# Altium schematic sheet besc drive sch.SchDoc
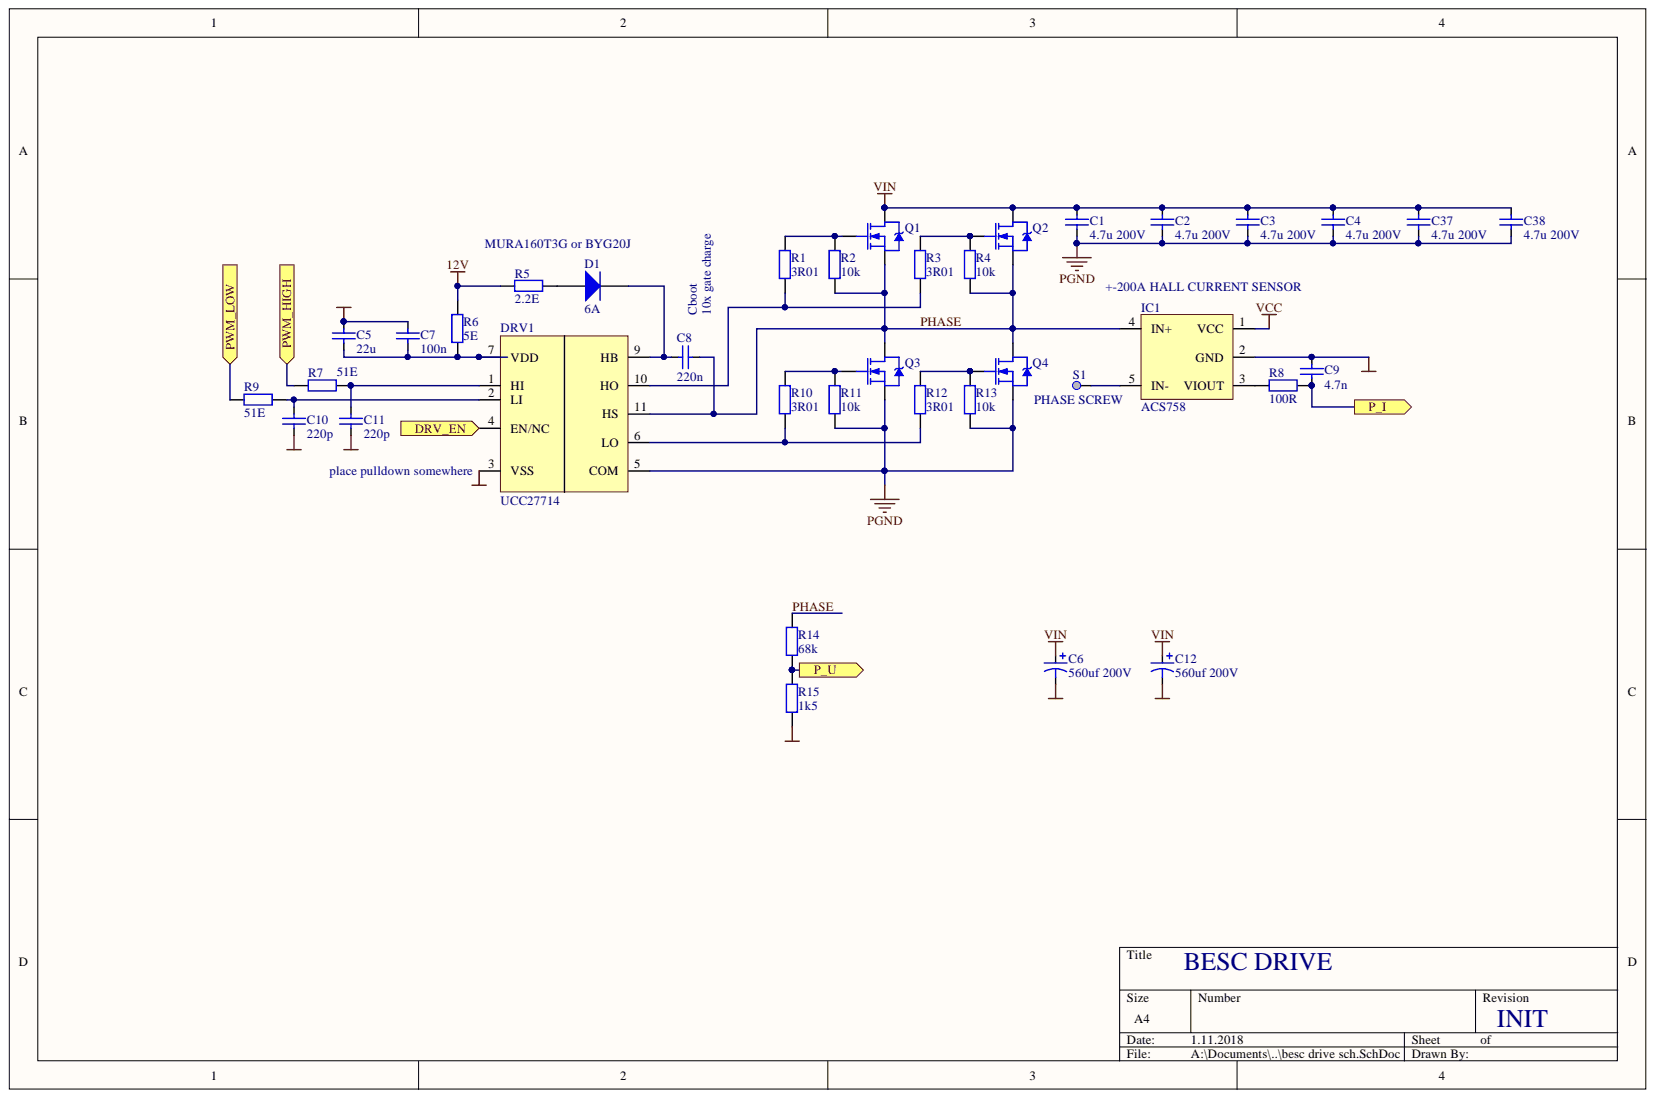
[diagram p0: besc drive sch.SchDoc]

## Parts
C1  4.7u 200V  [CAP 2220/5650 3.3MM]
C2  4.7u 200V  [CAP 2220/5650 3.3MM]
C3  4.7u 200V  [CAP 2220/5650 3.3MM]
C4  4.7u 200V  [CAP 2220/5650 3.3MM]
C5  22u  [0805]
C6  560uf 200V  [ELKO_18x40_90deg]
C7  100n  [0603]
C8  220n  [0603]
C9  4.7n  [0603]
C10  220p  [0603]
C11  220p  [0603]
C12  560uf 200V  [ELKO_18x40_90deg]
C37  4.7u 200V  [CAP 2220/5650 3.3MM]
C38  4.7u 200V  [CAP 2220/5650 3.3MM]
D1  6A  [DO-214AC]
DRV1  UCC27714  [SOIC14_M]
IC1  ACS758  [ACS758]
Q1  IPB044N15N5  [Dpak-7]  (value not drawn)
Q2  IPB044N15N5  [Dpak-7]  (value not drawn)
Q3  IPB044N15N5  [Dpak-7]  (value not drawn)
Q4  IPB044N15N5  [Dpak-7]  (value not drawn)
R1  3R01  [0603]
R2  10k  [0603]
R3  3R01  [0603]
R4  10k  [0603]
R5  2.2E  [0805]
R6  5E  [0603]
R7  51E  [0603]
R8  100R  [0603]
R9  51E  [0603]
R10  3R01  [0603]
R11  10k  [0603]
R12  3R01  [0603]
R13  10k  [0603]
R14  68k  [0603]
R15  1k5  [0603]
S1  PHASE SCREW  [WP-SHFU-M6]

## Ports
DRV_EN, P_I, P_U, PWM_HIGH, PWM_LOW

## Nets
12V: R5.2 R6.1
LGND: C5.2 C7.2 C9.2 C10.2 C11.2 DRV1.3(VSS) IC1.2(GND) R15.2
PGND: C1.1 C2.1 C3.1 C4.1 C6.2 C12.2 C37.1 C38.1 DRV1.5(COM) Q3.2 Q4.2 R11.2 R13.2
VCC: IC1.1(VCC)
VIN: C1.2 C2.2 C3.2 C4.2 C6.1 C12.1 C37.2 C38.2 Q1.3 Q2.3
DRV_EN: DRV1.4(EN/NC) ; port DRV_EN
P_I: C9.1 R8.1 ; port P_I
P_U: R14.2 R15.1 ; port P_U
PHASE: C8.1 DRV1.11(HS) IC1.4(IN+) Q1.2 Q2.2 Q3.3 Q4.3 R2.2 R4.2 R14.1
PWM_HIGH: R7.2 ; port PWM_HIGH
PWM_LOW: R9.1 ; port PWM_LOW
NetC5_1: C5.1 C7.1 DRV1.7(VDD) R6.2
NetC8_2: C8.2 D1.2 DRV1.9(HB)
NetC10_1: C10.1 DRV1.2(LI) R9.2
NetC11_1: C11.1 DRV1.1(HI) R7.1
NetD1_1: D1.1 R5.1
NetDRV1_6: DRV1.6(LO) R10.2 R12.2
NetDRV1_10: DRV1.10(HO) R1.2 R3.2
NetIC1_3: IC1.3(VIOUT) R8.2
NetIC1_5: IC1.5(IN-) S1.1
NetQ1_1: Q1.1 R1.1 R2.1
NetQ2_1: Q2.1 R3.1 R4.1
NetQ3_1: Q3.1 R10.1 R11.1
NetQ4_1: Q4.1 R12.1 R13.1

## Library data of the parts
C1-C5 C7-C11 C37 C38 = cap: Capacitor; Component Kind: Standard; LatestRevisionDate: 17-Jul-2002; LatestRevisionNote: Re-released for DXP Platform.; Library Name: Miscellaneous Devices.IntLib; PackageDescription: Radial Cap, Thru-Hole; 2 Leads; 0.3 in Pin Spacing; PackageReference: RAD-0.3; Published: 8-Jun-2000; Signal Integrity: Cap; Simulation: CAP; Publisher: Altium Limited
  C1: url: https://www.aliexpress.com/item/5pcs-SMD-capacitor-5750-2220-4-7UF-200V-250V-475K-X7R-10-Non-polarity/32633946304.html?spm=2114.search0104.3.15.470c328aPXtbgJ&ws_ab_test=searchweb0_0,searchweb201602_1_10065_10068_318_319_5731311_317_10696_5728811_10084_10083_10618_452_10304_10307_5731411_10820_532_10301_10821_5731211_5731111_204_328_10059_10884_323_10887_5731511_100031_5731611_320_321_322_5731711_10103,searchweb201603_55,ppcSwitch_0&algo_expid=2440df57-4916-4206-b13e-2c99e03a8745-2&algo_pvid=2440df57-4916-4206-b13e-2c99e03a8745
C6 C12 = Cap Pol1: Polarized Capacitor (Radial); Component Kind: Standard; LatestRevisionDate: 17-Jul-2002; LatestRevisionNote: Re-released for DXP Platform.; Library Name: Miscellaneous Devices.IntLib; PackageDescription: Polarized Capacitor (Radial); 2 Leads; PackageReference: RB7.6-15; Published: 8-Jun-2000; Signal Integrity: Cap Pol; Simulation: CAP; Publisher: Altium Limited
D1 = Diode 1N4148: High Conductance Fast Diode; Code_JEDEC: DO-204-AH; Component Kind: Standard; ComponentLink1Description: Manufacturer Link; ComponentLink1URL: http://www.fairchildsemi.com/; ComponentLink2Description: Datasheet; ComponentLink2URL: http://www.fairchildsemi.com/ds/1N%2F1N4148.pdf; DatasheetVersion: 1997; LatestRevisionDate: 17-Jul-2002; LatestRevisionNote: Re-released for DXP Platform.; Library Name: Miscellaneous Devices.IntLib; PackageDescription: Diode, Axial; Body 3.8 x 1.9 mm (LxDia. max), Lead Dia. 0.53 mm (max); PackageReference: DO-35; Published: 8-Jun-2000; Signal Integrity: 1N4148; Simulation: 1N4148; Publisher: Altium Limited
Q1-Q4 = NMOS-2: N-Channel Power MOSFET; Note: Pin 2 is electrically connected to pin 4.; Component Kind: Standard; ComponentLink1Description: Manufacturer Link; ComponentLink1URL: http://www.irf.com/; DatasheetVersion: PD-9.814; LatestRevisionDate: 17-Jul-2002; LatestRevisionNote: Re-released for DXP Platform.; Library Name: Miscellaneous Devices.IntLib; PackageDescription: TO, Thru-Hole, Vertical, Heatsink Mounted; 3 In-Line Leads; Pitch 2.54 mm; PackageReference: TO-220AB; PackageVersion: Feb-1997; Published: 8-Jun-2000; Simulation: IRF1010; Publisher: Altium Limited
R1-R15 = Res2: Resistor; Component Kind: Standard; LatestRevisionDate: 17-Jul-2002; LatestRevisionNote: Re-released for DXP Platform.; Library Name: Miscellaneous Devices.IntLib; PackageDescription: Axial Device, Thru-Hole; 2 Leads; 0.4 in Pin Spacing; PackageReference: AXIAL-0.4; Published: 8-Jun-2000; Signal Integrity: Res; Simulation: RESISTOR; Publisher: Altium Limited
S1 = En_pin: Component Kind: Standard; Library Name: moja.SchLib
DRV1 = UCC27714: Component Kind: Standard; Library Name: moja.SchLib
IC1 = ACS758: Component Kind: Standard; Library Name: moja.SchLib
